# Altium schematic sheet joint_board_boosterpack_can.SchDoc
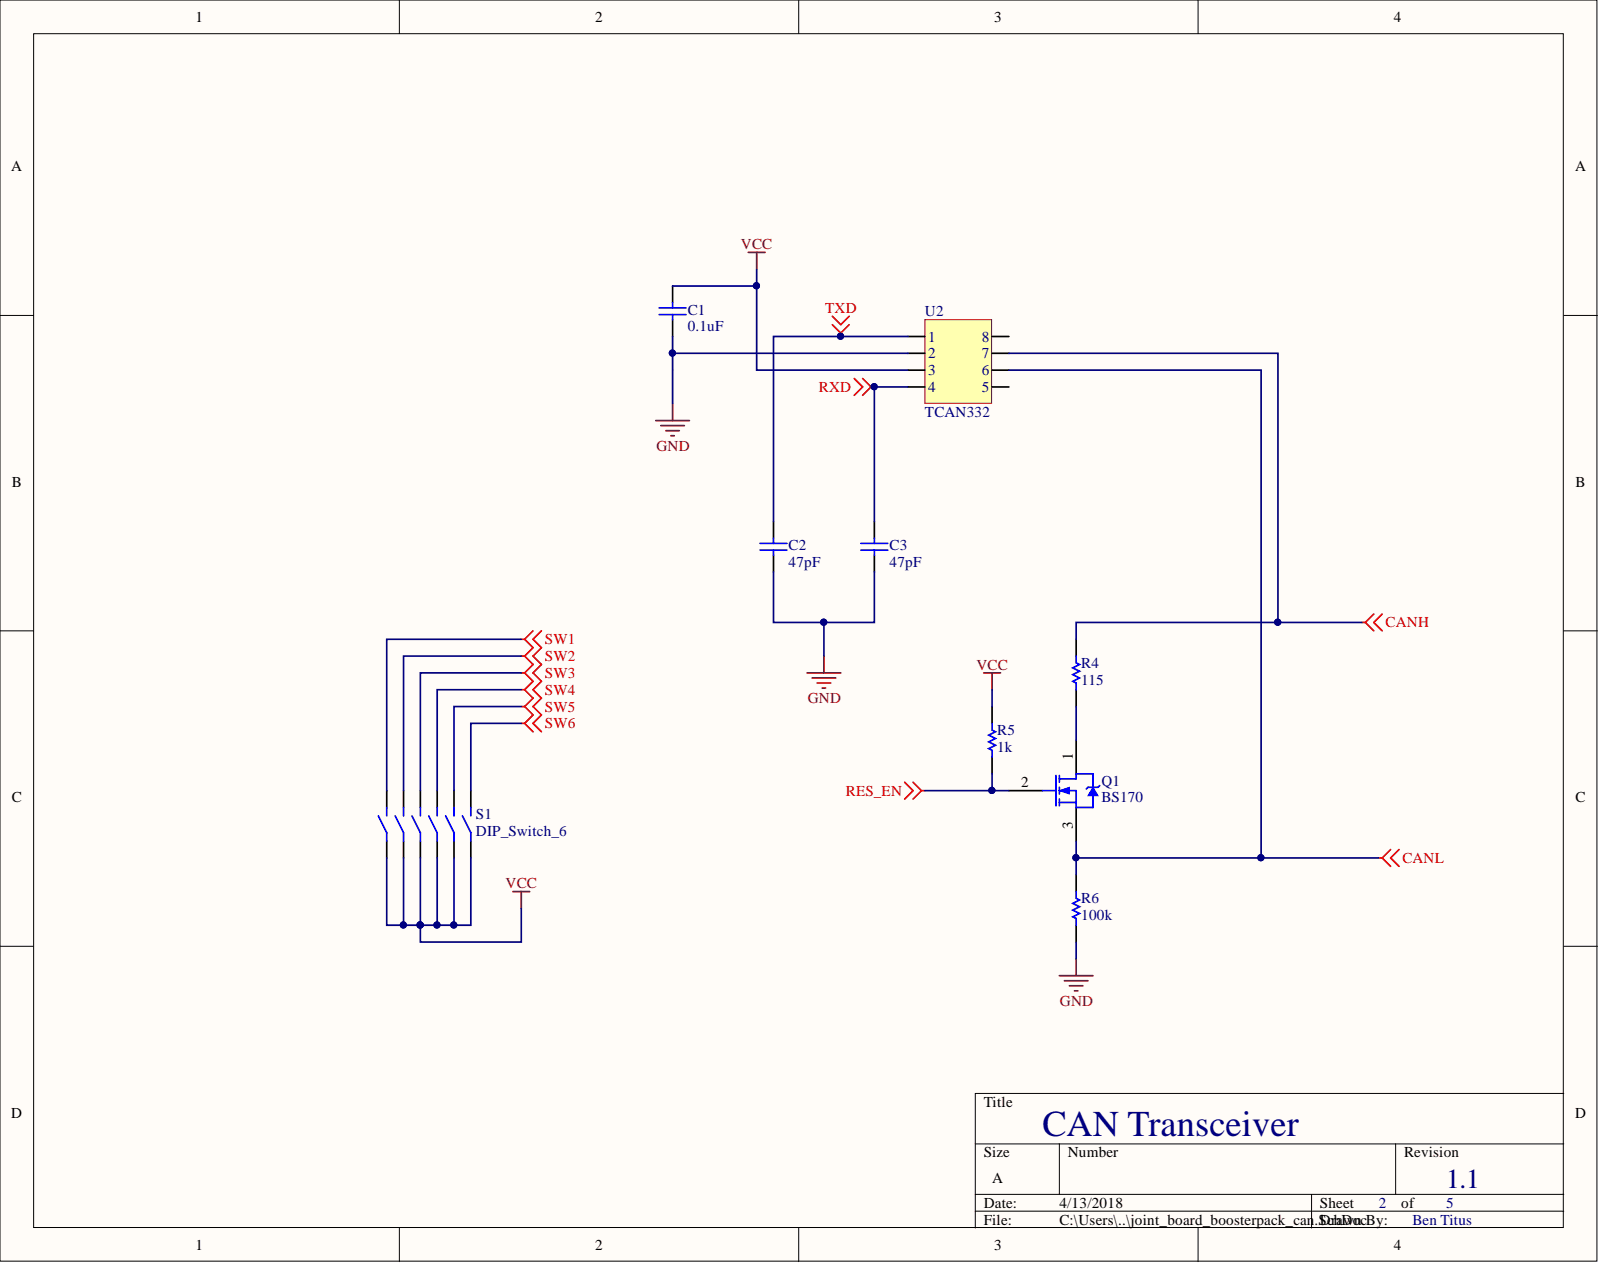
[diagram p0: joint_board_boosterpack_can.SchDoc]

## Parts
C1  0.1uF  [CAPC0805(2012)145_L]
C2  47pF  [CAPC0805(2012)145_L]
C3  47pF  [CAPC0805(2012)145_L]
Q1  BS170  [SOT23-3]
R4  115  [RESC0805(2012)_L]
R5  1k  [RESC0805(2012)_L]
R6  100k  [RESC0805(2012)_L]
S1  DIP_Switch_6  [SOP12]
U2  TCAN332  [SOP8]

## Nets
CANH: R4.2 U2.7
CANL: Q1.3 R6.2 U2.6
GND: C1.1 C2.1 C3.1 R6.1 U2.2
RES_EN: Q1.2 R5.1
RXD: C3.2 U2.4
SW1: S1.12
SW2: S1.11
SW3: S1.10
SW4: S1.9
SW5: S1.8
SW6: S1.7
TXD: C2.2 U2.1
VCC: C1.2 R5.2 S1.1 S1.2 S1.3 S1.4 S1.5 S1.6 U2.3
NetQ1_1: Q1.1 R4.1

Not connected: U2 5 8

## Library data of the parts
C1-C3 = CMP-1590-00003-1: 0805 (2012 Metric) Chip Capacitor; Case-EIA: 0805; Case-Metric: 2012; Component Kind: Standard; Library Name: Altium Content Vault; PackageReference: CAPC0805(2012)145
Q1 = BS170: Small Signal MOSFET, 500 mA, 60 V, N-Channel, 3-Pin TO-92, Bulk Box; Manufacturer: On Semiconductor; Channel Polarity: N Channel; Configuration: Single; ID Max (A): 0.5; PD Max (W): 0.35; V(BR)DSS Min (V): 60; VGS(th) Max (V): 3; VGS Max (V): 20; Component Kind: Standard; ComponentLink1Description: Manufacturer URL; ComponentLink1URL: http://www.onsemi.com/; ComponentLink2Description: Datasheet; ComponentLink2URL: http://www.onsemi.com/pub_link/Collateral/BS170-D.PDF; ComponentLink3Description: Package Specification; ComponentLink3URL: http://www.onsemi.cn/pub_link/Collateral/2N6426-D.PDF; DatasheetVersion: Rev. 6; Library Name: ON Semi MOSFETs.IntLib; Mounting Technology: Through Hole; PackageDescription: 3-Pin Transistor Outline, Body 4.825 x 3.685 mm, Pitch 1.27 mm; PackageReference: TO-92-3-29-11_AM_SL; Package Type: TO-92; PackageVersion: Rev. AM; Packing: Bulk Box; PartNumber: BS170; Supplier 1: Digi-Key; Supplier Part Number 1: BS170OS-ND
R4-R6 = CMP-1591-00002-1: 0805 (2012 Metric) Chip Resistor; Case-EIA: 0805; Case-Metric: 2012; Component Kind: Standard; Library Name: Altium Content Vault; PackageReference: RESC0805(2012)
S1 = DIP_Switch_6: 6 pin DIP switch; Component Kind: Standard; Library Name: Custom_Lib.IntLib
U2 = TCAN332: 3.3V CAN Transceiver; Component Kind: Standard; Library Name: TCAN332.SchLib
